# Altium schematic sheet Sche_power.SchDoc(Sche_power)
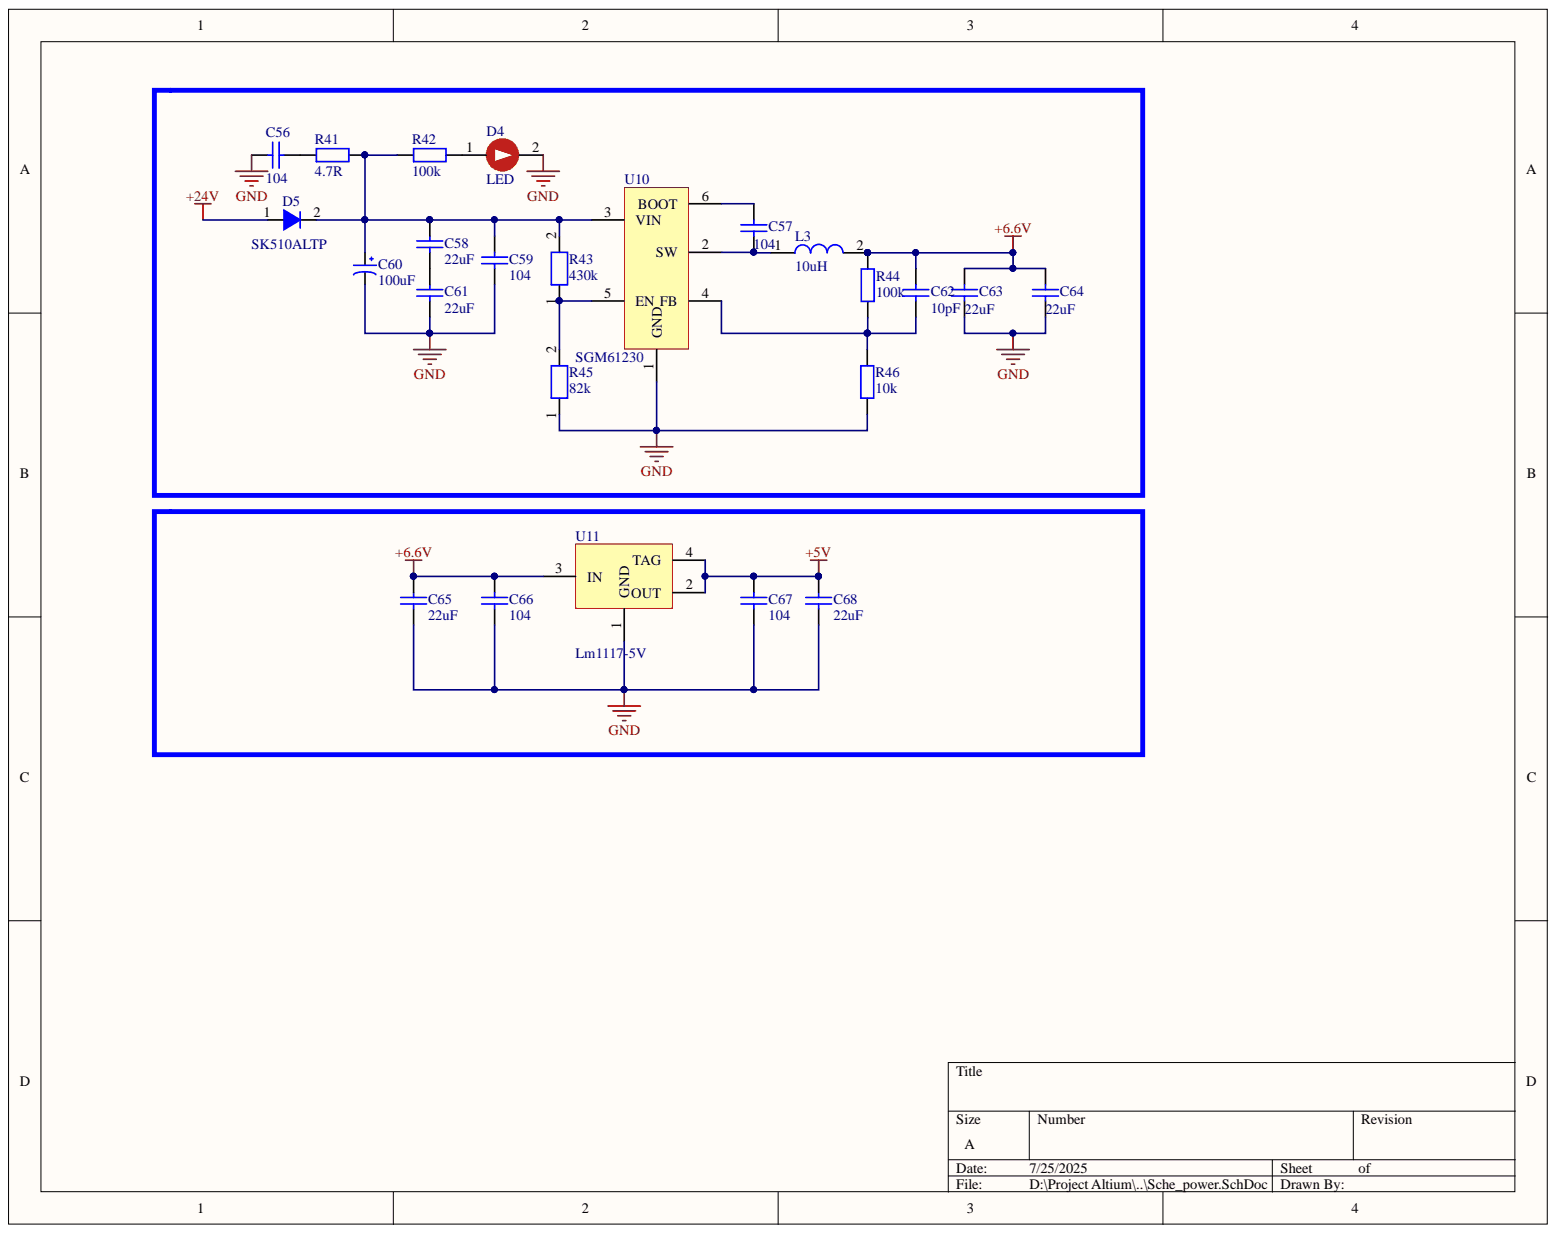
[diagram p0: Sche_power.SchDoc]

## Parts
C56  104  [tu 0603]
C57  104  [tu 0603]
C58  22uF  [Tu 0805]
C59  104  [tu 0805]
C60  100uF  [tu hoa 6.5mm]
C61  22uF  [Tu 0805]
C62  10pF  [Tu 0603]
C63  22uF  [CAP 0603_1608]
C64  22uF  [CAP 0603_1608]
C65  22uF  [Tu 0805]
C66  104  [tu 0603]
C67  104  [tu 0603]
C68  22uF  [Tu 0805]
D4  LED  [LED0603]
D5  SK510ALTP  [SK510ALTP]
L3  10uH  [CDRH6D38NP-100NC]
R41  4.7R  [tro smd 0603]
R42  100k  [tro smd 0603]
R43  430k  [TRO SMD 0603]
R44  100k  [tro smd 0603]
R45  82k  [TRO SMD 0603]
R46  10k  [tro smd 0603]
U10  SGM61230  [SGM61230]
U11  Lm1117-5V  [LM1117IMPX-5.0]

## Nets
+5V: C67.1 C68.2 U11.2(OUT) U11.4(TAG)
+6.6V: C62.1 C63.2 C64.2 C65.2 C66.1 L3.2 R44.2 U11.3(IN)
+24V: D5.1
GND: C56.2 C59.2 C60.2 C61.1 C63.1 C64.1 C65.1 C66.2 C67.2 C68.1 D4.2 R45.1 R46.2 U10.1(GND) U11.1(GND)
NetC56_1: C56.1 R41.1
NetC57_1: C57.1 U10.6(BOOT)
NetC57_2: C57.2 L3.1 U10.2(SW)
NetC58_1: C58.1 C61.2
NetC58_2: C58.2 C59.1 C60.1 D5.2 R41.2 R42.1 R43.2 U10.3(VIN)
NetC62_2: C62.2 R44.1 R46.1 U10.4(FB)
NetD4_1: D4.1 R42.2
NetR43_1: R43.1 R45.2 U10.5(EN)

## Library data of the parts
C56 C57 C66 C67 = Tu 0603 104 50V 10%: Dán; Ten_Linh_Kien: Tụ 0603 104-100nF 10% 50V; So_Chan: 2; Ma_Hang: MH004568; Don_Vi: Con; Library Name: Tu dien.SchLib; Component Kind: Standard; Chung_Loai: Dán
C58 C61 C65 C68 = 0805-22uF: 0805 22uF; Library Name: test.SchLib; Component Kind: Standard
C59 = Tu 0805 104 50V 10%: Dán; Ten_Linh_Kien: Tụ 0805 104-100nF 10% 50V; So_Chan: 2; Ma_Hang: MH004551; Don_Vi: Con; Library Name: Tu dien.SchLib; Component Kind: Standard; Chung_Loai: Dán
C60 = Tu Hoa 100uF 35V 6x8: Cắm; Ten_Linh_Kien: Tụ Hóa 100uF 35V; So_Chan: 2; Ma_Hang: MH006862; Don_Vi: Con; Library Name: Tu dien.SchLib; Component Kind: Standard; Chung_Loai: Cắm
C62 = 0603_10pF: Integrated Circuit; Manufacturer_Part_Number: ams1117-3.3v; Manufacturer_Name: Advanced Monolithic Systems; Library Name: test.SchLib; Height: 1.8mm; Datasheet Link: http://www.advanced-monolithic.com/pdf/ds1117.pdf; Component Kind: Standard
C63 C64 = 0603_22uF_16V: Integrated Circuit; Manufacturer_Part_Number: ams1117-3.3v; Manufacturer_Name: Advanced Monolithic Systems; Library Name: test.SchLib; Height: 1.8mm; Datasheet Link: http://www.advanced-monolithic.com/pdf/ds1117.pdf; Component Kind: Standard
D4 = LED 0603 RED: LED RED SMD 0603; Library Name: test.SchLib; Component Kind: Standard
D5 = SK510A_LTP: Diode SK510A SCHOTTKY; Library Name: test.SchLib; Component Kind: Standard
L3 = CDRH6D38NP-100NC: Inductor 10uH CDRH6D38NP-100NC 7x7x4mm; Library Name: test.SchLib; Component Kind: Standard
R41 = Tro 0603 1% 56R: Dán; Ten_Linh_Kien: Trở 0603 1% 56R; So_Chan: 2; Ma_Hang: MH006338; Don_Vi: Con; Library Name: Dien Tro.SchLib; Component Kind: Standard; Chung_Loai: Dán
R42 R44 = Tro 0603 5% 100k: Dán; Ten_Linh_Kien: Trở 0603 5% 100k; So_Chan: 2; Ma_Hang: MH006314; Don_Vi: Con; Library Name: Dien Tro.SchLib; Component Kind: Standard; Chung_Loai: Dán
R43 = R0603_430k_1%: Resistor; Manufacturer_Part_Number: CR0603-FX-1202ELF; Manufacturer_Name: Bourns; Mouser Price/Stock: https://www.mouser.com/Search/Refine.aspx?Keyword=652-CR0603FX-1202ELF; Mouser Part Number: 652-CR0603FX-1202ELF; Library Name: test.SchLib; Height: 0.55mm; Datasheet Link: https://www.bourns.com/pdfs/chpreztr.pdf; Component Kind: Standard; Arrow Price/Stock: https://www.arrow.com/en/products/cr0603-fx-1202elf/bourns; Arrow Part Number: CR0603-FX-1202ELF
R45 = R0603_82k_1%: Resistor; Manufacturer_Part_Number: CR0603-FX-1202ELF; Manufacturer_Name: Bourns; Mouser Price/Stock: https://www.mouser.com/Search/Refine.aspx?Keyword=652-CR0603FX-1202ELF; Mouser Part Number: 652-CR0603FX-1202ELF; Library Name: test.SchLib; Height: 0.55mm; Datasheet Link: https://www.bourns.com/pdfs/chpreztr.pdf; Component Kind: Standard; Arrow Price/Stock: https://www.arrow.com/en/products/cr0603-fx-1202elf/bourns; Arrow Part Number: CR0603-FX-1202ELF
R46 = Tro 0603 5% 10k: Dán; Ten_Linh_Kien: Trở 0603 5% 10k; So_Chan: 2; Ma_Hang: MH006316; Don_Vi: Con; Library Name: Dien Tro.SchLib; Component Kind: Standard; Chung_Loai: Dán
U11 = Lm1117IMPX-5V: LM1117IMPX-5.0; Library Name: test.SchLib; Component Kind: Standard
U10 = SGM61230: Library Name: test.SchLib; Description: SGM61230; Component Kind: Standard
